# Altium schematic sheet BotInd.SchDoc(BotInd)
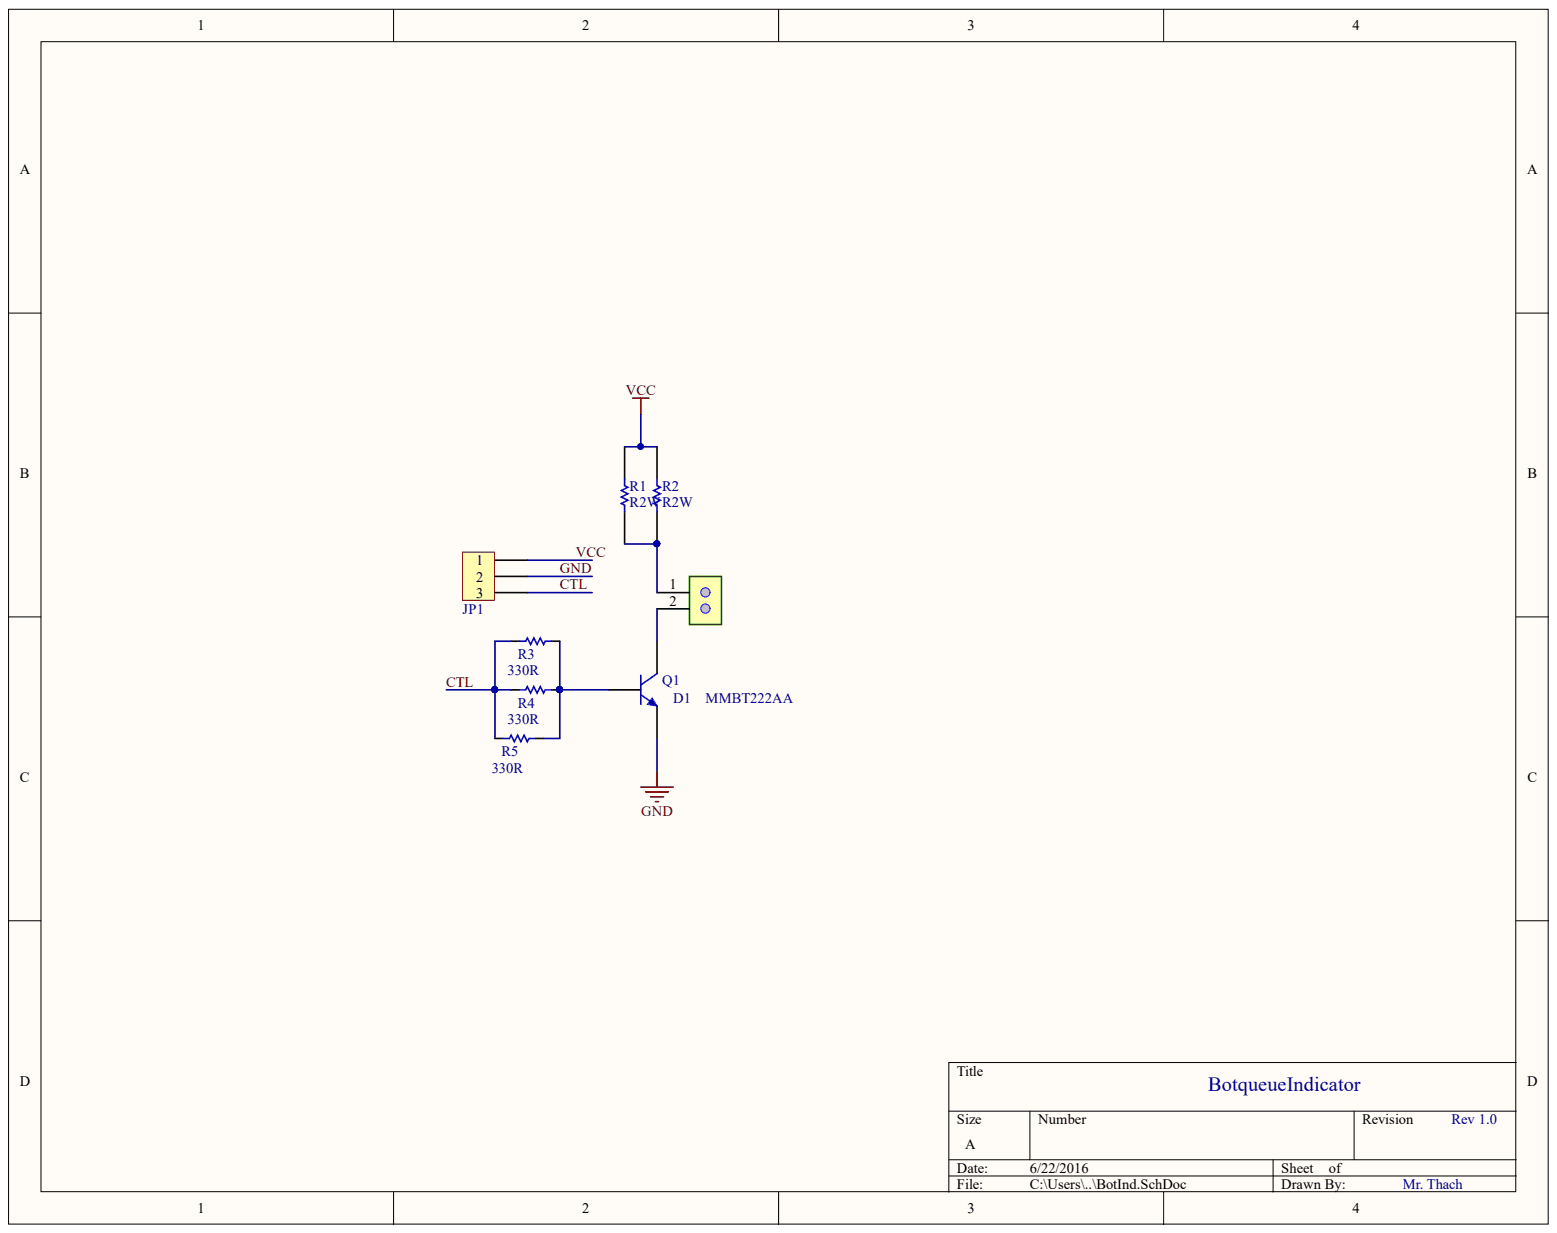
[diagram p0: BotInd.SchDoc]

## Parts
D1  DOMINO  [Domino2_350]  (value not drawn)
JP1  HEAD3  [HEAD3]  (value not drawn)
Q1  MMBT222AA  [SOT23-3]
R1  R2W  [R 2W]
R2  R2W  [R 2W]
R3  0805_R  [0805_R]  (value not drawn)
R4  0805_R  [0805_R]  (value not drawn)
R5  0805_R  [0805_R]  (value not drawn)

## Nets
CTL: JP1.3 R3.2 R4.2 R5.2
GND: JP1.2 Q1.2
VCC: JP1.1 R1.1 R2.1
NetD1_1: D1.1 R1.2 R2.2
NetD1_2: D1.2 Q1.3
NetQ1_1: Q1.1 R3.1 R4.1 R5.1

## Library data of the parts
D1 = Domino2_small: Component Kind: Standard; Library Name: Thach.SchLib
JP1 = HEAD3: Connector 3; Component Kind: Standard; Value: Connector 3; Library Name: Thach.SchLib
Q1 = MMBT222AA: NPN General Purpose Amplifier; Component Kind: Standard; ComponentLink1Description: Manufacturer Link; ComponentLink1URL: http://www.fairchildsemi.com/; ComponentLink2Description: Datasheet; ComponentLink2URL: http://www.fairchildsemi.com/ds/2N%2F2N3904.pdf; DatasheetVersion: 1997; LatestRevisionDate: 15-Jan-2003; LatestRevisionNote: PCB Footprint 'BCY-W3/D4.7' replaced; PackageDescription: TO, Flat Index; 3 In-Line, Axial Leads; Body Dia. 4.5mm; Leads 0.51 x 0.48 mm (max); PackageReference: TO-92A; PackageVersion: Sep-1998; Published: 8-Jun-2000; Library Name: Thach.SchLib; Publisher: Altium Limited
R3-R5 = 0805_R: 0805 SMD resistor; : 330R; Component Kind: Standard; Library Name: Thach.SchLib
R1 R2 = R2W: Component Kind: Standard; Description: R2W; Library Name: Thach.SchLib
